# Altium schematic sheet power dis.SchDoc
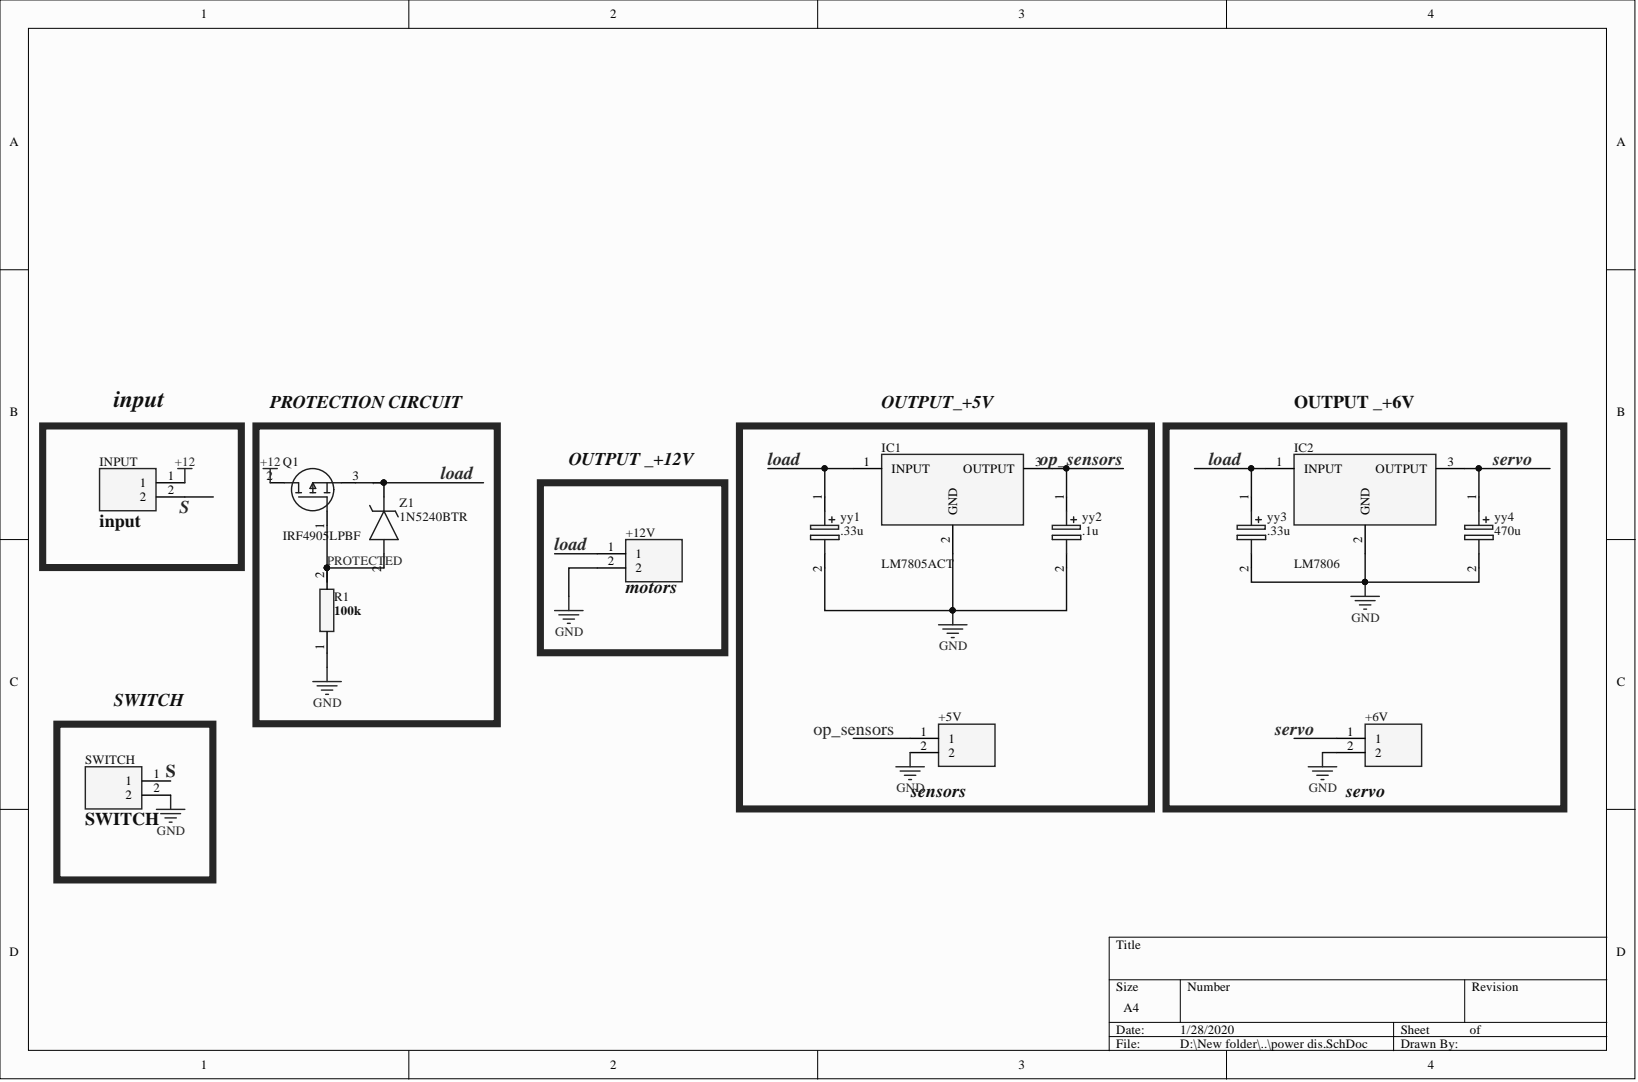
[diagram p0: power dis.SchDoc]

## Parts
+5V  sensors  [SHDR2W90P0X500_1X2_1000X750X1000P]
+6V  servo  [SHDR2W90P0X500_1X2_1000X750X1000P]
+12V  motors  [SHDR2W90P0X500_1X2_1000X750X1000P]
IC1  LM7805ACT  [TO254P470X1016X2019-3P]
IC2  LM7806  [TO254P470X1016X2019-3P]
INPUT  input  [SHDR2W90P0X500_1X2_1000X750X1000P]
Q1  IRF4905LPBF  [TO254P483X1016X1501-3P]
R1  100k  [RESAD1650W60L650D250]
SWITCH  SWITCH  [SHDR2W90P0X500_1X2_1000X750X1000P]
yy1  .33u  [CAPPRD500W60D1050H2200]
yy2  .1u  [CAPPRD500W60D1050H2200]
yy3  .33u  [CAPPRD500W60D1050H2200]
yy4  470u  [CAPPRD500W60D1050H2200]
Z1  1N5240BTR  [DIOAD1068W53L380D172]

## Nets
+12: INPUT.1 Q1.2
GND: +5V.2 +6V.2 +12V.2 IC1.2 IC2.2 R1.1 SWITCH.2 yy1.2 yy2.2 yy3.2 yy4.2
load: +12V.1 IC1.1 IC2.1 Q1.3 yy1.1 yy3.1 Z1.1
op_sensors: +5V.1 IC1.3 yy2.1
PROTECTED: Q1.1 R1.2 Z1.2
S: INPUT.2 SWITCH.1
servo: +6V.1 IC2.3 yy4.1

## Library data of the parts
+5V +6V +12V INPUT SWITCH = 30.702_BLUE: Connector; Manufacturer_Name: Altech; Manufacturer_Part_Number: 30.702/BLUE; Component Kind: Standard; Datasheet Link: https://componentsearchengine.com/Datasheets/1/30.702_BLUE.pdf; Height: 10mm; Mouser Part Number: 845-30.702/BLU; Mouser Price/Stock: https://www.mouser.com/Search/Refine.aspx?Keyword=845-30.702%2FBLU
IC1 IC2 = LM7805ACT: Integrated Circuit; Manufacturer_Name: ON Semiconductor; Component Kind: Standard; Datasheet Link: https://componentsearchengine.com/Datasheets/2/LM7805ACT.pdf; Height: 4.7mm; Manufacturer_Part_Number: LM7805ACT; Mouser Part Number: 512-LM7805ACT; Mouser Price/Stock: https://www.mouser.com/Search/Refine.aspx?Keyword=512-LM7805ACT; RS Part Number: 8062839; RS Price/Stock: http://uk.rs-online.com/web/p/products/8062839
Q1 = IRF4905LPBF: MOSFET (P-Channel); Manufacturer_Name: Infineon; Allied_Number: 70017189; Allied Price/Stock: https://www.alliedelec.com/infineon-irf4905lpbf/70017189/; Component Kind: Standard; Datasheet Link: https://componentsearchengine.com/Datasheets/2/IRF4905LPBF.pdf; Height: 4.83mm; Manufacturer_Part_Number: IRF4905LPBF; Mouser Part Number: 942-IRF4905LPBF; Mouser Price/Stock: https://www.mouser.com/Search/Refine.aspx?Keyword=942-IRF4905LPBF; RS Part Number: 6503662P; RS Price/Stock: http://uk.rs-online.com/web/p/products/6503662P
R1 = MRS25000C1002FC100: Resistor; Manufacturer_Name: Vishay; Component Kind: Standard; Datasheet Link: http://www.vishay.com/docs/28724/mrs16m25.pdf; Library Name: h bridge.IntLib; Manufacturer_Part_Number: MRS25000C1002FC100; Mouser Part Number: 594-2322-156-11003; Mouser Price/Stock: https://www.mouser.com/Search/Refine.aspx?Keyword=594-2322-156-11003
yy1-yy4 = 100ZLJ100M10X20: Capacitor Polarised; Manufacturer_Name: Rubycon; Component Kind: Standard; Datasheet Link: http://www.rubycon.co.jp/en/catalog/e_pdfs/aluminum/e_zlj.pdf; Height: 22mm; Library Name: h bridge.IntLib; Manufacturer_Part_Number: 100ZLJ100M10X20; RS Part Number: 7497319P; RS Price/Stock: http://uk.rs-online.com/web/p/products/7497319P
Z1 = 1N5240BTR: Zener Diode; Manufacturer_Name: ON Semiconductor; Component Kind: Standard; Datasheet Link: https://componentsearchengine.com/Datasheets/2/1N5240BTR.pdf; Manufacturer_Part_Number: 1N5240BTR; Mouser Part Number: 512-1N5240BTR; Mouser Price/Stock: https://www.mouser.com/Search/Refine.aspx?Keyword=512-1N5240BTR; RS Part Number: 8050160P; RS Price/Stock: http://uk.rs-online.com/web/p/products/8050160P
